# Altium schematic sheet Output.SchDoc(Output)
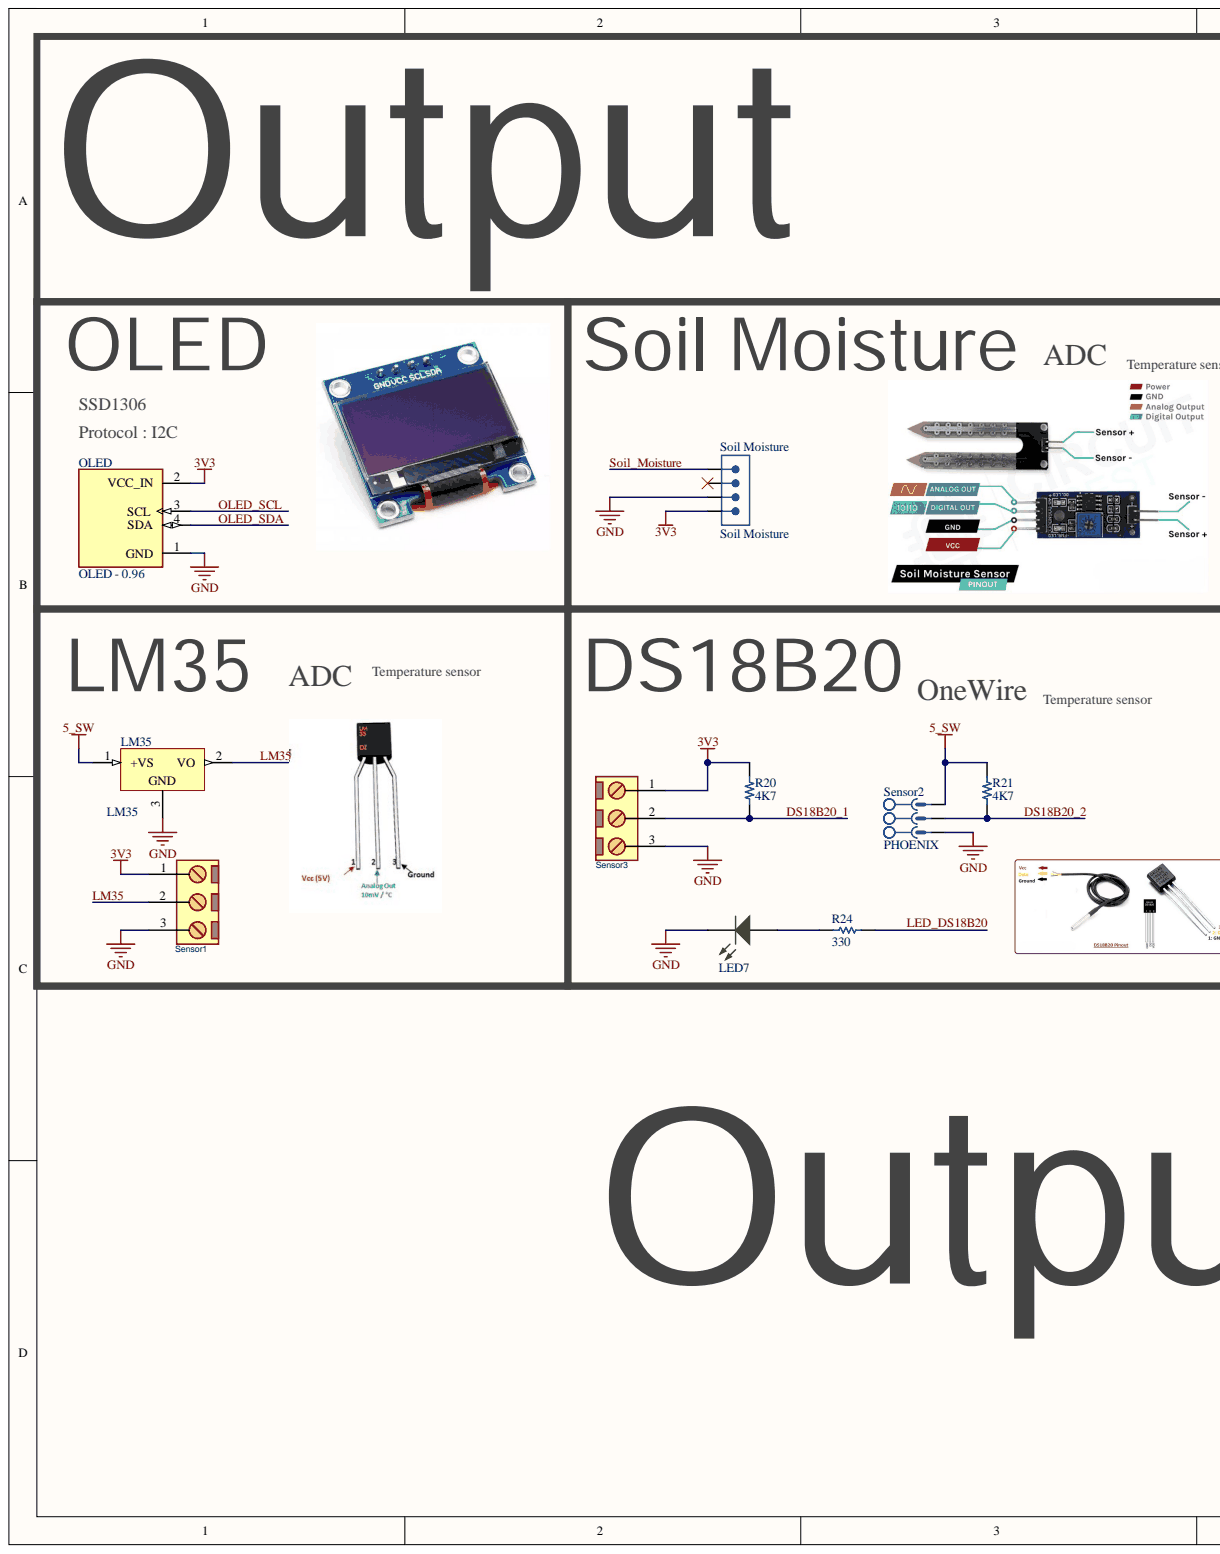
[diagram p0: Output.SchDoc, left]
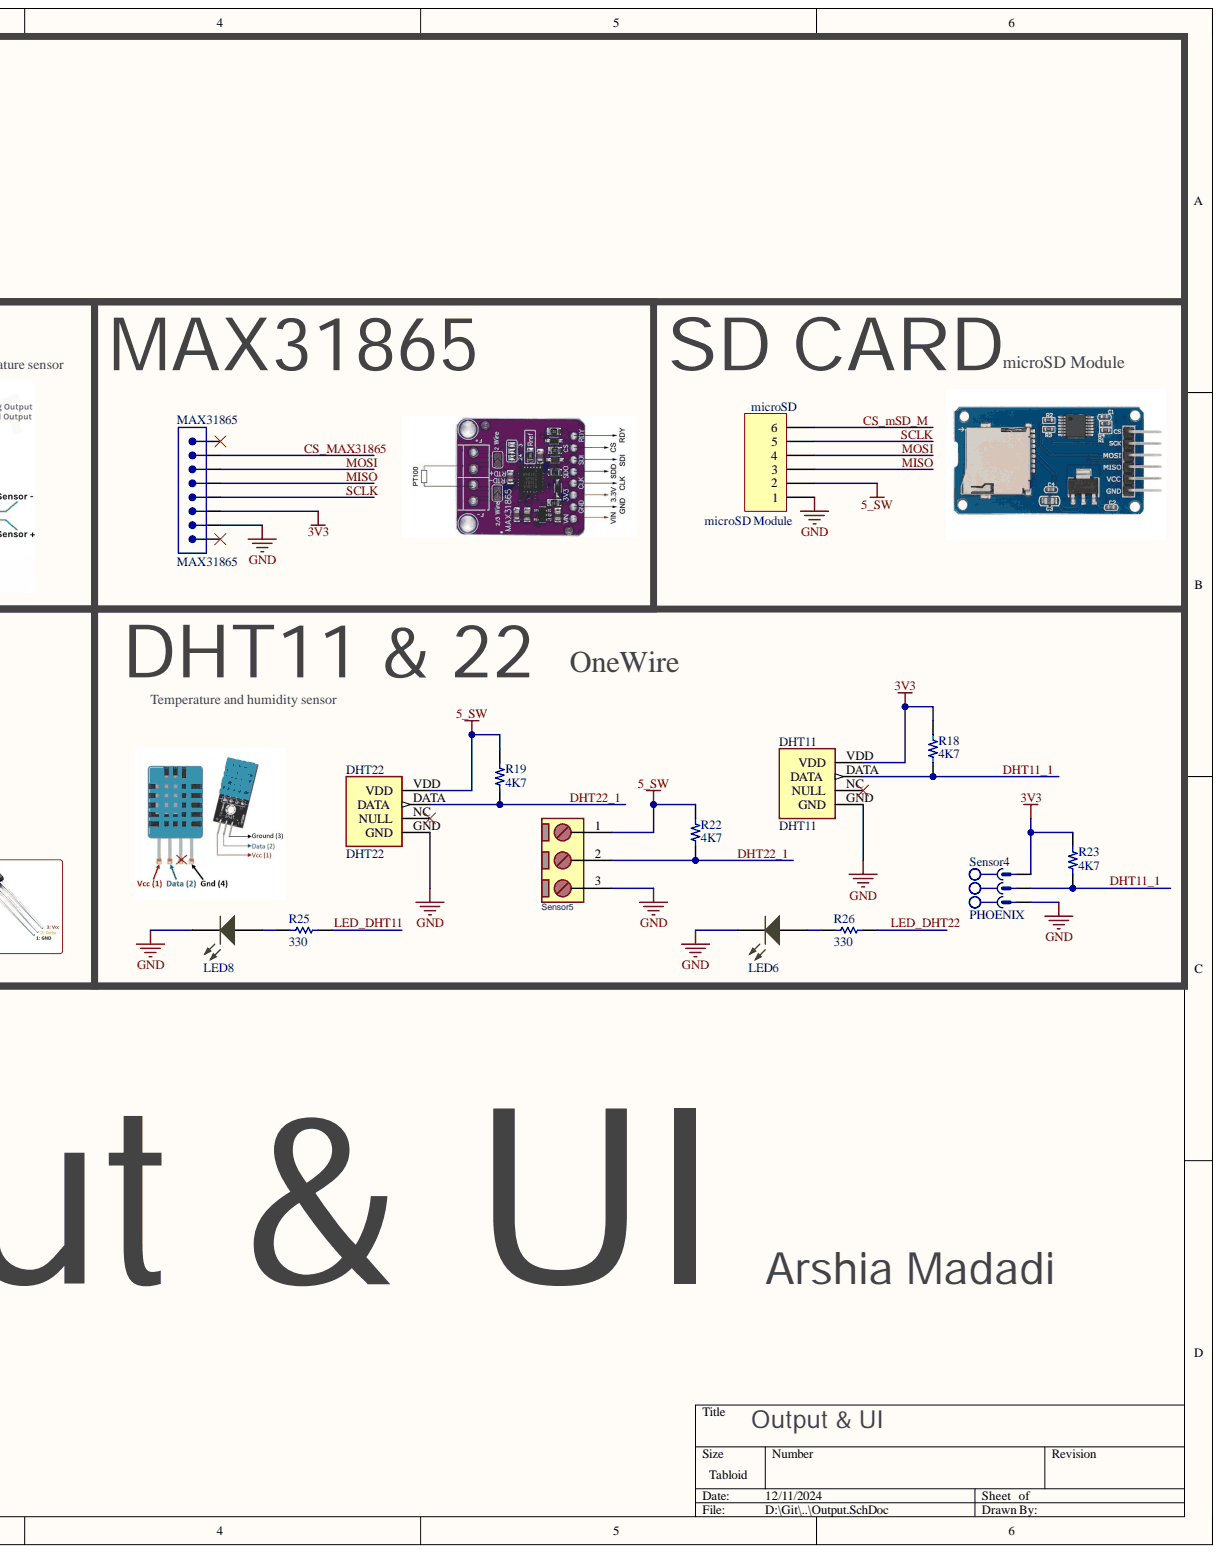
[diagram p1: Output.SchDoc, right]

## Parts
DHT11  DHT11  [DHT22]
DHT22  DHT22  [DHT22]
LED6  LED SMD 0805 GREEN  [LED_0805_GREEN]  (value not drawn)
LED7  LED SMD 0805 GREEN  [LED_0805_GREEN]  (value not drawn)
LED8  LED SMD 0805 GREEN  [LED_0805_GREEN]  (value not drawn)
LM35  LM35  [Z03A]
MAX31865  MAX31865  [MHDR1X08-254P]
microSD  microSD Module  [HDR1X6-1]
OLED  OLED - 0.96  [MODULE_DM-OLED096-636]
R18  4K7  [RES 0603_1608]
R19  4K7  [RES 0603_1608]
R20  4K7  [RES 0603_1608]
R21  4K7  [RES 0603_1608]
R22  4K7  [RES 0603_1608]
R23  4K7  [RES 0603_1608]
R24  330  [RESC3413X04N]
R25  330  [RESC3413X04N]
R26  330  [RESC3413X04N]
Sensor1  Phoenix MSTBV+F 3Pin  [PHOENIX MSTBVF_3]  (value not drawn)
Sensor2  PHOENIX  [MSTB 2,5/3-G-5,08]
Sensor3  Phoenix MSTBV+F 3Pin  [PHOENIX MSTBVF_3]  (value not drawn)
Sensor4  PHOENIX  [MSTB 2,5/3-G-5,08]
Sensor5  Phoenix MSTBV+F 3Pin  [PHOENIX MSTBVF_3]  (value not drawn)
Soil_Moisture  Soil Moisture  [MHDR1X04-254P]

## Nets
3V3: DHT11.VDD MAX31865.6 OLED.2(VCC_IN) R18.2 R20.2 R23.2 Sensor1.1 Sensor3.1 Sensor4.1 Soil_Moisture.4
5_SW: DHT22.VDD LM35.1 microSD.2 R19.2 R21.2 R22.2 Sensor2.1 Sensor5.1
GND: DHT11.GND DHT22.GND LED6.1 LED7.2 LED8.2 LM35.3 MAX31865.7 microSD.1 OLED.1(GND) Sensor1.3 Sensor2.3 Sensor3.3 Sensor4.3 Sensor5.3 Soil_Moisture.3
CS_MAX31865: MAX31865.2
CS_mSD_M: microSD.6
DHT11_1: DHT11.DATA R18.1 R23.1 Sensor4.2
DHT22_1: DHT22.DATA R19.1 R22.1 Sensor5.2
DS18B20_1: R20.1 Sensor3.2
DS18B20_2: R21.1 Sensor2.2
LED_DHT11: R25.1
LED_DHT22: R26.1
LED_DS18B20: R24.1
LM35: LM35.2 Sensor1.2
MISO: MAX31865.4 microSD.3
MOSI: MAX31865.3 microSD.4
OLED_SCL: OLED.3(SCL)
OLED_SDA: OLED.4(SDA)
SCLK: MAX31865.5 microSD.5
Soil_Moisture: Soil_Moisture.1
NetLED6_2: LED6.2 R26.2
NetLED7_1: LED7.1 R24.2
NetLED8_1: LED8.1 R25.2

Not connected: DHT11 NC(NULL); DHT22 NC(NULL); MAX31865 1 8; Soil_Moisture 2

## Library data of the parts
DHT11 DHT22 = DHT22: Capacitive-type humidity and temperature module/sensor; MF: Aosong Electronics; Check_prices: https://www.snapeda.com/parts/DHT22/Aosong+Electronics/view-part/?ref=eda; Component Kind: Standard; Library Name: DHT22.IntLib; MP: DHT22; Package: Package; SnapEDA_Link: https://www.snapeda.com/parts/DHT22/Aosong+Electronics/view-part/?ref=snap
LM35 = LM35CAZ: Precision Centigrade Temperature Sensor; Manufacturer: National Semiconductor; CompType: Temp Sensor IC; PackageTopMarking: NSXYTT*LM35*CAZ; PowerWise: N; Code_JEDEC: TO-226-AA; Component Kind: Standard; ComponentLink1Description: LM35 Datasheet; ComponentLink1URL: http://www.national.com/ds/LM/LM35.pdf; ComponentLink2Description: LM35 Web Page; ComponentLink2URL: http://www.national.com/pf/LM/LM35.html; ComponentLink3Description: Z03A Package Page; ComponentLink3URL: http://www.national.com/packaging/folders/z03a.html; DatasheetVersion: Nov-2000; DigiKeyPartNumber: LM35CAZ-ND; LatestRevisionDate: 15-Jul-2009; LatestRevisionNote: Sch pins 1 & 3 reversed.; PackageDescription: TO-92, Molded, 3 Lead; PackageReference: Z03A; PackageVersion: revG, Sep-2000; PartNumber: LM35CAZ; PinCount: 3; Published: 4-Dec-2008; RoHS: O; Library Name: Sajad_Altium_Library.IntLib; Publisher: Altium Limited
MAX31865 = MHDR_1X08: Male Header 1X08; Component Kind: Standard; Library Name: SJ Sockets & Connectors.IntLib
microSD = Header 6: Header, 6-Pin; Component Kind: Standard; LatestRevisionDate: 17-Jul-2002; LatestRevisionNote: Re-released for DXP Platform.; Library Name: Altium.IntLib; Signal Integrity: Connector; Publisher: Altium Limited
OLED = DM-OLED096-636: 0.96â€ 128 X 64 MONOCHROME GRAPHIC OLED DISPLAY MODULE - I2C; Check_prices: https://www.snapeda.com/parts/DM-OLED096-636/Display+Module/view-part/?ref=eda; Component Kind: Standard; Library Name: DM-OLED096-636.IntLib; MF: Display Module; MP: DM-OLED096-636; Package: Package; SnapEDA_Link: https://www.snapeda.com/parts/DM-OLED096-636/Display+Module/view-part/?ref=snap
R18-R23 = Res 0603: VALUE: 1K; Component Kind: Standard; Description: RES 0603; Library Name: Resistor.IntLib
R24-R26 = RES 0805 0.125W: RES 0805; Desc.: RES SMD 0805; Designer: Abbas Basereh; Component Kind: Standard; Data: 16-12-2016; Library Name: Altium.IntLib
Sensor1 Sensor3 Sensor5 = Phoenix MSTBV+F 3Pin: CONN, PHOENIX MSTBV, 5-G, 5.08mm pitch; PartNumber: MSTBV 2,5/ 2-G-5,08 - 1758018 + MSTB 2,5/ 3-ST-5,08 - 1757022; Component Kind: Standard; Library Name: Conn Terminal.IntLib
Sensor2 Sensor4 = CON_Phoenix_MSTB_03: 3 Pitch: 5.08 mm Color: green Contact surface: Tin Assembly; Manufacturer: PHOENIX CONTACT; Connector type: PCB Terminal Block; designer: ABBAS BASEREH; Max Current: 12 A; Positions: 3; Voltage: 320 V; Category: Connector; Component Kind: Standard; ComponentLink1Description: Header, Nominal current: 12 A Rated voltage (III/2): 320 V Number of positions: 3 Pitch: 5.08 mm Color: green Contact surface: Tin Assembly: Soldering  DESIGNER:ABBAS BASEREH; ComponentLink2Description: Reference; Library Name: Altium.IntLib; Mounting Technology: Through-Hole; PART.NO: PHOENIX; Pin Count: 3; Revision 1: 2017-3-1; Signal Integrity: CON-3P; Status: Unreleased
Soil_Moisture = MHDR_1X04: Male Header 1X04; Component Kind: Standard; Library Name: SJ Sockets & Connectors.IntLib
LED6-LED8 = LED SMD 0805 GREEN: Component Kind: Standard; Library Name: Led.IntLib
